# Altium schematic sheet switch 2.SchDoc("switch 2")
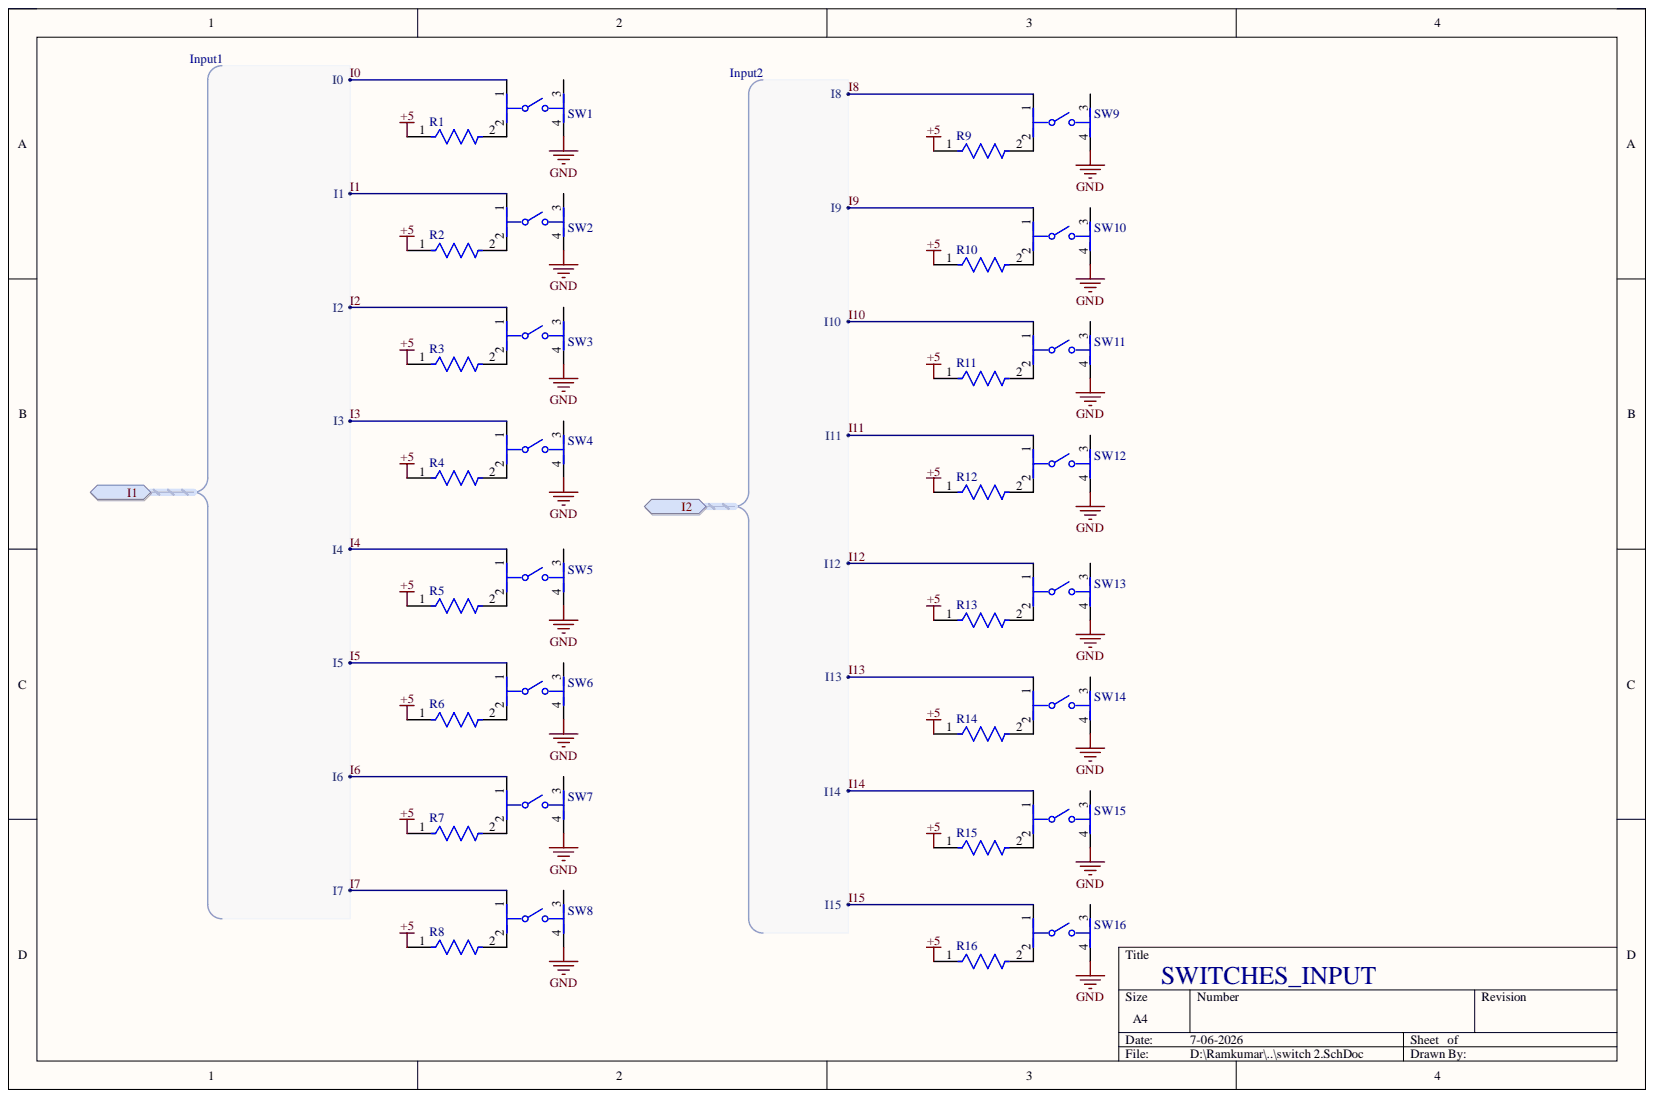
[diagram p0: switch 2.SchDoc]

## Parts
R1  MRS25000C1001FCT00  [FP-MRS25-MFG]  (value not drawn)
R2  MRS25000C1001FCT00  [FP-MRS25-MFG]  (value not drawn)
R3  MRS25000C1001FCT00  [FP-MRS25-MFG]  (value not drawn)
R4  MRS25000C1001FCT00  [FP-MRS25-MFG]  (value not drawn)
R5  MRS25000C1001FCT00  [FP-MRS25-MFG]  (value not drawn)
R6  MRS25000C1001FCT00  [FP-MRS25-MFG]  (value not drawn)
R7  MRS25000C1001FCT00  [FP-MRS25-MFG]  (value not drawn)
R8  MRS25000C1001FCT00  [FP-MRS25-MFG]  (value not drawn)
R9  MRS25000C1001FCT00  [FP-MRS25-MFG]  (value not drawn)
R10  MRS25000C1001FCT00  [FP-MRS25-MFG]  (value not drawn)
R11  MRS25000C1001FCT00  [FP-MRS25-MFG]  (value not drawn)
R12  MRS25000C1001FCT00  [FP-MRS25-MFG]  (value not drawn)
R13  MRS25000C1001FCT00  [FP-MRS25-MFG]  (value not drawn)
R14  MRS25000C1001FCT00  [FP-MRS25-MFG]  (value not drawn)
R15  MRS25000C1001FCT00  [FP-MRS25-MFG]  (value not drawn)
R16  MRS25000C1001FCT00  [FP-MRS25-MFG]  (value not drawn)
SW1  1-1825910-5  [FP-1-1825910-5-MFG]  (value not drawn)
SW2  1-1825910-5  [FP-1-1825910-5-MFG]  (value not drawn)
SW3  1-1825910-5  [FP-1-1825910-5-MFG]  (value not drawn)
SW4  1-1825910-5  [FP-1-1825910-5-MFG]  (value not drawn)
SW5  1-1825910-5  [FP-1-1825910-5-MFG]  (value not drawn)
SW6  1-1825910-5  [FP-1-1825910-5-MFG]  (value not drawn)
SW7  1-1825910-5  [FP-1-1825910-5-MFG]  (value not drawn)
SW8  1-1825910-5  [FP-1-1825910-5-MFG]  (value not drawn)
SW9  1-1825910-5  [FP-1-1825910-5-MFG]  (value not drawn)
SW10  1-1825910-5  [FP-1-1825910-5-MFG]  (value not drawn)
SW11  1-1825910-5  [FP-1-1825910-5-MFG]  (value not drawn)
SW12  1-1825910-5  [FP-1-1825910-5-MFG]  (value not drawn)
SW13  1-1825910-5  [FP-1-1825910-5-MFG]  (value not drawn)
SW14  1-1825910-5  [FP-1-1825910-5-MFG]  (value not drawn)
SW15  1-1825910-5  [FP-1-1825910-5-MFG]  (value not drawn)
SW16  1-1825910-5  [FP-1-1825910-5-MFG]  (value not drawn)

## Ports
I1, I1.I0, I1.I1, I1.I2, I1.I3, I1.I4, I1.I5, I1.I6, I1.I7, I2, I2.I8, I2.I9, I2.I10, I2.I11, I2.I12, I2.I13, I2.I14, I2.I15

## Nets
+5: R1.1 R2.1 R3.1 R4.1 R5.1 R6.1 R7.1 R8.1 R9.1 R10.1 R11.1 R12.1 R13.1 R14.1 R15.1 R16.1
GND: SW1.4 SW2.4 SW3.4 SW4.4 SW5.4 SW6.4 SW7.4 SW8.4 SW9.4 SW10.4 SW11.4 SW12.4 SW13.4 SW14.4 SW15.4 SW16.4
I0: SW1.1 ; port I1
I1: SW2.1 ; port I1
I2: SW3.1 ; port I1
I3: SW4.1 ; port I1
I4: SW5.1 ; port I1
I5: SW6.1 ; port I1
I6: SW7.1 ; port I1
I7: SW8.1 ; port I1
I8: SW9.1 ; port I2
I9: SW10.1 ; port I2
I10: SW11.1 ; port I2
I11: SW12.1 ; port I2
I12: SW13.1 ; port I2
I13: SW14.1 ; port I2
I14: SW15.1 ; port I2
I15: SW16.1 ; port I2
NetR1_2: R1.2 SW1.2
NetR2_2: R2.2 SW2.2
NetR3_2: R3.2 SW3.2
NetR4_2: R4.2 SW4.2
NetR5_2: R5.2 SW5.2
NetR6_2: R6.2 SW6.2
NetR7_2: R7.2 SW7.2
NetR8_2: R8.2 SW8.2
NetR9_2: R9.2 SW9.2
NetR10_2: R10.2 SW10.2
NetR11_2: R11.2 SW11.2
NetR12_2: R12.2 SW12.2
NetR13_2: R13.2 SW13.2
NetR14_2: R14.2 SW14.2
NetR15_2: R15.2 SW15.2
NetR16_2: R16.2 SW16.2

Not connected: SW1 3; SW2 3; SW3 3; SW4 3; SW5 3; SW6 3; SW7 3; SW8 3; SW9 3; SW10 3; SW11 3; SW12 3; SW13 3; SW14 3; SW15 3; SW16 3

## Library data of the parts
R1-R16 = CMP-02408-000051-1: RES 1K OHM 0.6W 1% AXIAL; Lead Diameter: 0.6mm; Max Power Dissipation: 600mW; Resistance: 1kR; Temperature Coefficient: 50ppm/°C; Voltage Rating: 350V; Case/Package: Axial; Component Kind: Standard; Construction: Cylindrical; Contact Plating: Tin; Diameter: 2.5mm; Lead Free: Lead Free; Length: 6.5mm; Library Name: Altium Content Vault; Max Operating Temperature: 155°C; Military Standard: Not; Min Operating Temperature: -55°C; Mount: Through Hole; Number of Pins: 2; Number of Terminations: 2; Package Quantity: 5000; Packaging: Tape and Reel; Power Rating: 600mW; Radiation Hardening: No; Resistance Tolerance: 1%; Resistor Type: Metal Film; RoHS Compliant: Yes; Series: MRS25; Technology: THIN FILM; Termination: Axial; Tolerance: 1%; Voltage Rating (DC): 350V; Weight: 0.00776oz; Width: 2.5mm
SW1-SW16 = CMP-03407-000006-1: SWITCH TACTILE SPST-NO 0.05A 24V; Actuator Color: Red; Actuator Length: 5.9mm; Actuator Material: PBT; Actuator Type: Standard; Contact Current Rating: 50mA; Contact Resistance: 100mR; Electrical Life: 100000Cycles; Illumination Type: Non-Illuminated; Operating Force: 2.55N; Switch Function: Off-Mom; Switch Travel: 0.25mm; Throw Configuration: SPST; Component Kind: Standard; Contact Material: Brass; Contact Plating: Silver; Length: 5.99mm; Library Name: Altium Content Vault; Max Current Rating: 50mA; Max Operating Temperature: 85°C; Max Voltage Rating (DC): 24V; Mechanical Life: 100000Cycles; Min Operating Temperature: -35°C; Mount: Through Hole; Orientation: Straight; Package Quantity: 750; Packaging: Loose Piece; Radiation Hardening: No; RoHS Compliant: Yes; Series: FSMJ, Alcoswitch; Termination: PC Pins; Type: Switch; Voltage Rating (DC): 24V
